# Altium schematic sheet SHEET_3.SchDoc
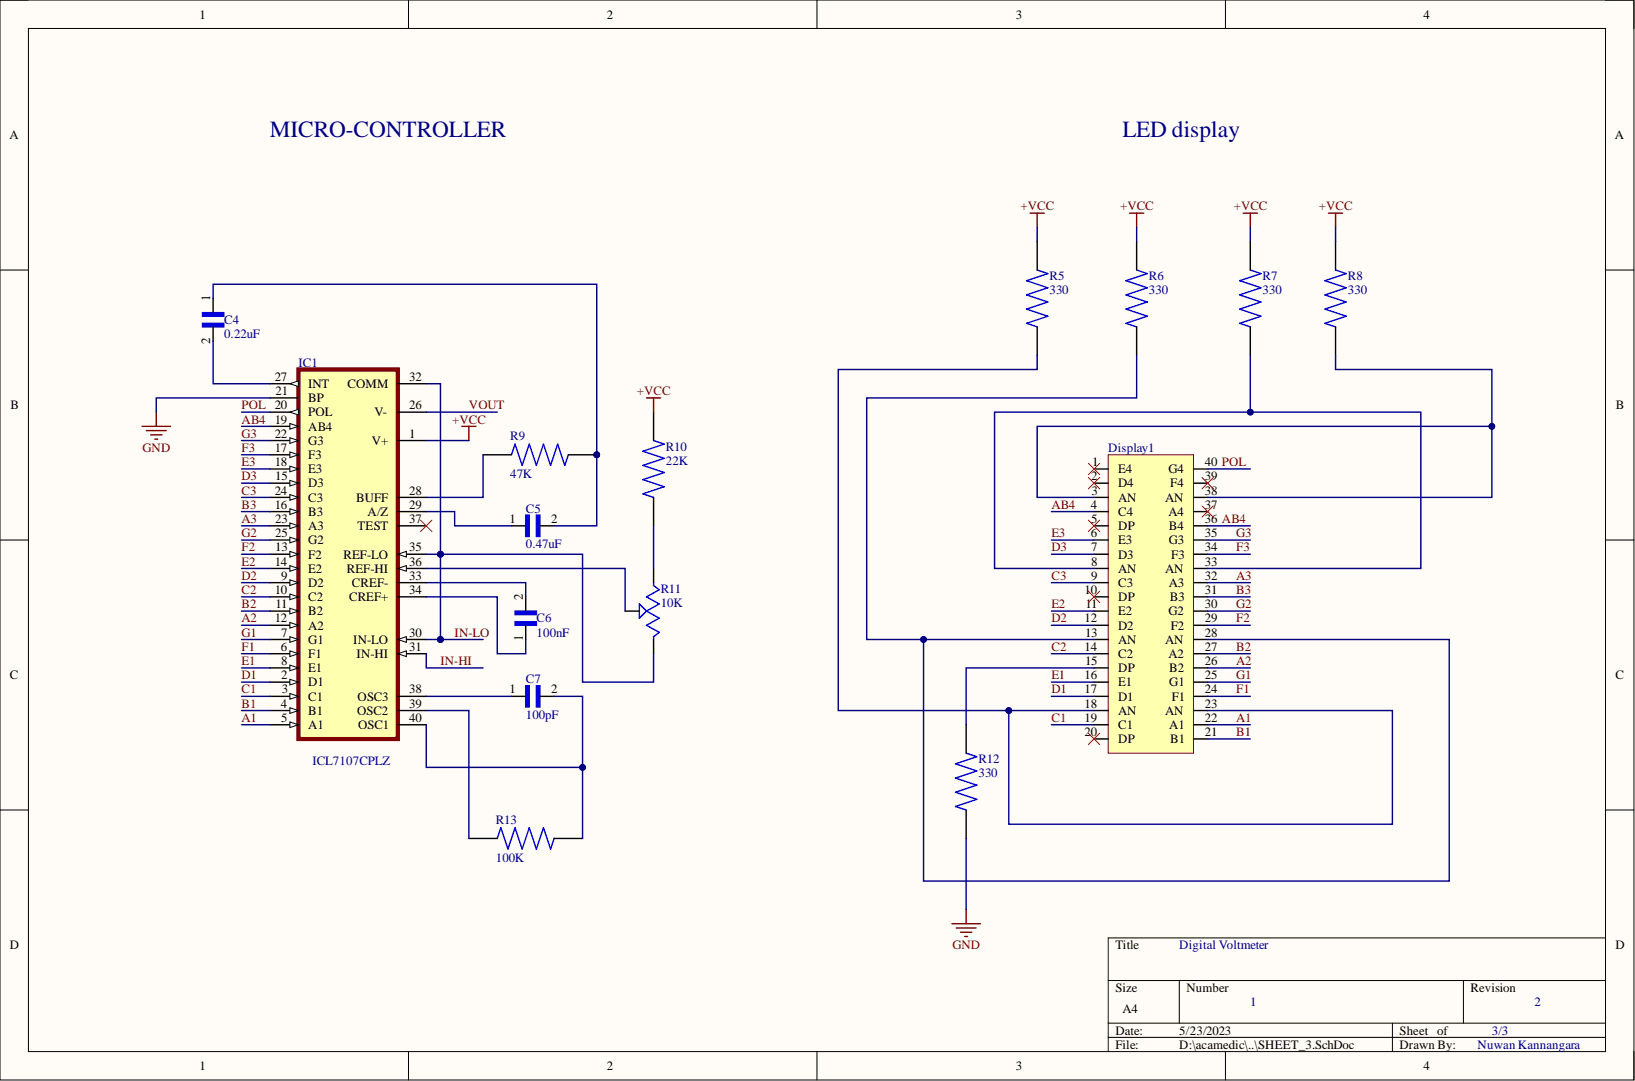
[diagram p0: SHEET_3.SchDoc]

## Parts
C4  0.22uF  [CAPRB500W50L550T350H600]
C5  0.47uF  [CAPRB500W50L550T350H600]
C6  100nF  [CAPRB500W50L550T350H600]
C7  100pF  [CAPRB500W50L550T350H600]
Display1  (no value)  [DIL40]
IC1  ICL7107CPLZ  [DIL40]
R5  330  [RESAD1100W55L680D260]
R6  330  [RESAD1100W55L680D260]
R7  330  [RESAD1100W55L680D260]
R8  330  [RESAD1100W55L680D260]
R9  47K  [RESAD1100W55L680D260]
R10  22K  [RESAD1100W55L680D260]
R11  10K  [TRIM_3296P-1-502LF]
R12  330  [RESAD1100W55L680D260]
R13  100K  [RESAD1100W55L680D260]

## Nets
+VCC: IC1.1(V+) R5.2 R6.2 R7.2 R8.2 R10.2
GND: IC1.21(BP) R12.1
A1: Display1.22(A1) IC1.5(A1)
A2: Display1.26(B2) IC1.12(A2)
A3: Display1.32(A3) IC1.23(A3)
AB4: Display1.4(C4) Display1.36(B4) IC1.19(AB4)
B1: Display1.21(B1) IC1.4(B1)
B2: Display1.27(A2) IC1.11(B2)
B3: Display1.31(B3) IC1.16(B3)
C1: Display1.19(C1) IC1.3(C1)
C2: Display1.14(C2) IC1.10(C2)
C3: Display1.9(C3) IC1.24(C3)
D1: Display1.17(D1) IC1.2(D1)
D2: Display1.12(D2) IC1.9(D2)
D3: Display1.7(D3) IC1.15(D3)
E1: Display1.16(E1) IC1.8(E1)
E2: Display1.11(E2) IC1.14(E2)
E3: Display1.6(E3) IC1.18(E3)
F1: Display1.24(F1) IC1.6(F1)
F2: Display1.29(F2) IC1.13(F2)
F3: Display1.34(F3) IC1.17(F3)
G1: Display1.25(G1) IC1.7(G1)
G2: Display1.30(G2) IC1.25(G2)
G3: Display1.35(G3) IC1.22(G3)
IN-HI: IC1.31(IN-HI)
IN-LO: IC1.30(IN-LO) IC1.32(COMM) IC1.35(REF-LO) R11.3
POL: Display1.40(G4) IC1.20(POL)
VOUT: IC1.26(V-)
NetC4_1: C4.1 C5.2 R9.2
NetC4_2: C4.2 IC1.27(INT)
NetC5_1: C5.1 IC1.29(A/Z)
NetC6_1: C6.1 IC1.34(CREF+)
NetC6_2: C6.2 IC1.33(CREF-)
NetC7_1: C7.1 IC1.38(OSC3)
NetC7_2: C7.2 IC1.40(OSC1) R13.2
NetDisplay1_3: Display1.3(AN) Display1.38(AN) R8.1
NetDisplay1_8: Display1.8(AN) Display1.33(AN) R7.1
NetDisplay1_13: Display1.13(AN) Display1.28(AN) R6.1
NetDisplay1_15: Display1.15(DP) R12.2
NetDisplay1_18: Display1.18(AN) Display1.23(AN) R5.1
NetIC1_28: IC1.28(BUFF) R9.1
NetIC1_36: IC1.36(REF-HI) R11.2
NetIC1_39: IC1.39(OSC2) R13.1
NetR10_1: R10.1 R11.1

Not connected: Display1 1(E4) 2(D4) 5(DP) 10(DP) 20(DP) 37(A4) 39(F4); IC1 37(TEST)

## Library data of the parts
C4-C7 = FG26X7R2E104KNT06: 0.1ÂµF Â±10% 250V Ceramic Capacitor X7R Radial; MF: TDK; Value: $0.51; Availability: In Stock; Check_prices: https://www.snapeda.com/parts/FG26X7R2E104KNT06/TDK/view-part/?ref=eda; Component Kind: Standard; Library Name: FG26X7R2E104KNT06.SchLib; MP: FG26X7R2E104KNT06; Package: Radial TDK; Price: None; Purchase-URL: https://pricing.snapeda.com/search/part/FG26X7R2E104KNT06/?ref=eda; SnapEDA_Link: https://www.snapeda.com/parts/FG26X7R2E104KNT06/TDK/view-part/?ref=snap; Supplier 1: Mouser
Display1 = 7 segmented display: DISPLAY 7SEG 0.56" SGL GRN 10DIP x4; part name: LDSC512RI; MP: Lumex Optoelectronics; check price: https://www.snapeda.com/parts/LDSC512RI/Lumex%20Optoelectronics/view-part/?ref=search&t=LDSC512RI; Component Kind: Standard; ComponentLink1Description: link; ComponentLink1URL: https://www.snapeda.com/parts/LDSC512RI/Lumex%20Optoelectronics/view-part/?ref=search&t=LDSC512RI; Library Name: Schlib1.SchLib; Supplier 1: Mouser
IC1 = ICL7107CPLZ: Display Driver 3.5 Digit 40-DIP; MF: Renesas Electronics; Value: $11.2; Component Kind: Standard; ComponentLink1Description: New link; Digi-Key_Part_Number: ICL7107CPLZ-ND; Digi-Key_Purchase_URL: https://www.digikey.in/product-detail/en/renesas-electronics-america-inc/ICL7107CPLZ/ICL7107CPLZ-ND/821475?utm_source=snapeda&utm_medium=aggregator&utm_campaign=symbol; Library Name: SnapEDAsch.SchLib; MP: ICL7107CPLZ; Package: DIP-40 Intersil; SnapEDA_Link: https://www.snapeda.com/parts/ICL7107CPLZ/Renesas%20Electronics%20America%20Inc./view-part/45306/?ref=snap; Supplier 1: Mouser
R5-R10 R12 R13 = MFR-25FBF52-1K: 1.78 kOhms Â±1% 0.25W, 1/4W Through Hole Resistor Axial - Metal Film; MF: Yageo; Value: $0.05; Availability: In Stock; Check_prices: https://www.snapeda.com/parts/MFR-25FBF52-1K/Yageo/view-part/?ref=eda; Component Kind: Standard; Library Name: MFR-25FBF52-1K.SchLib; MP: MFR-25FBF52-1K; Package: Axial Yageo; Price: None; Purchase-URL: https://pricing.snapeda.com/search/part/MFR-25FBF52-1K/?ref=eda; SnapEDA_Link: https://www.snapeda.com/parts/MFR-25FBF52-1K/Yageo/view-part/?ref=snap; Supplier 1: Mouser
R11 = 3296P-1-502LF: Trimmer Cermet 5 kOhm linear 500 mW | Bourns 3296P1502LF @ Multi-turn trimmer potentiometer, cermet (Trimmer Cermet 5 kOhm linear 500 mW Trimmer Potentiometers).; Value: $1.92; Availability: In Stock; Check_prices: https://www.snapeda.com/parts/3296P-1-502LF/Bourns/view-part/?ref=eda; Component Kind: Standard; Library Name: 3296P-1-502LF.SchLib; MF: Bourns; MP: 3296P-1-502LF; Package: NON-STANDARD-3 Bourns; Price: None; Purchase-URL: https://pricing.snapeda.com/search/part/3296P-1-502LF/?ref=eda; SnapEDA_Link: https://www.snapeda.com/parts/3296P-1-502LF/Bourns/view-part/?ref=snap; Supplier 1: Arrow Electronics
